# Altium schematic sheet PowerSupply.SchDoc(PowerSupply)
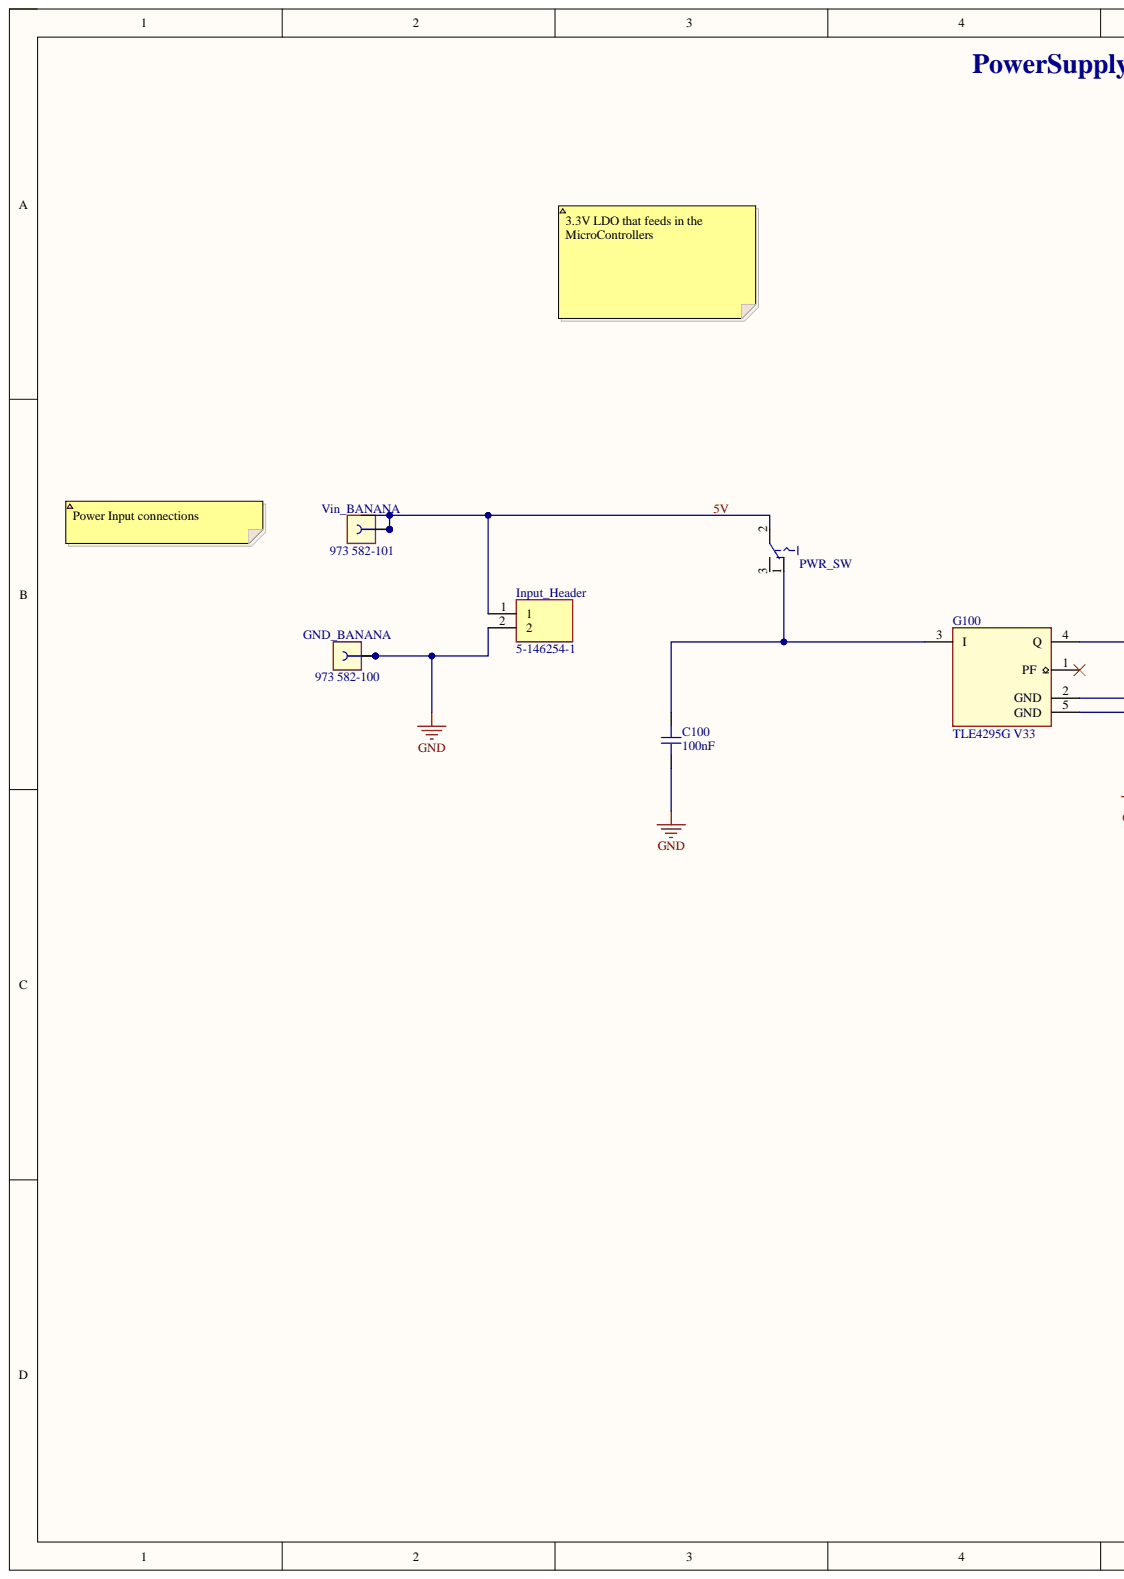
[diagram p0: PowerSupply.SchDoc, left]
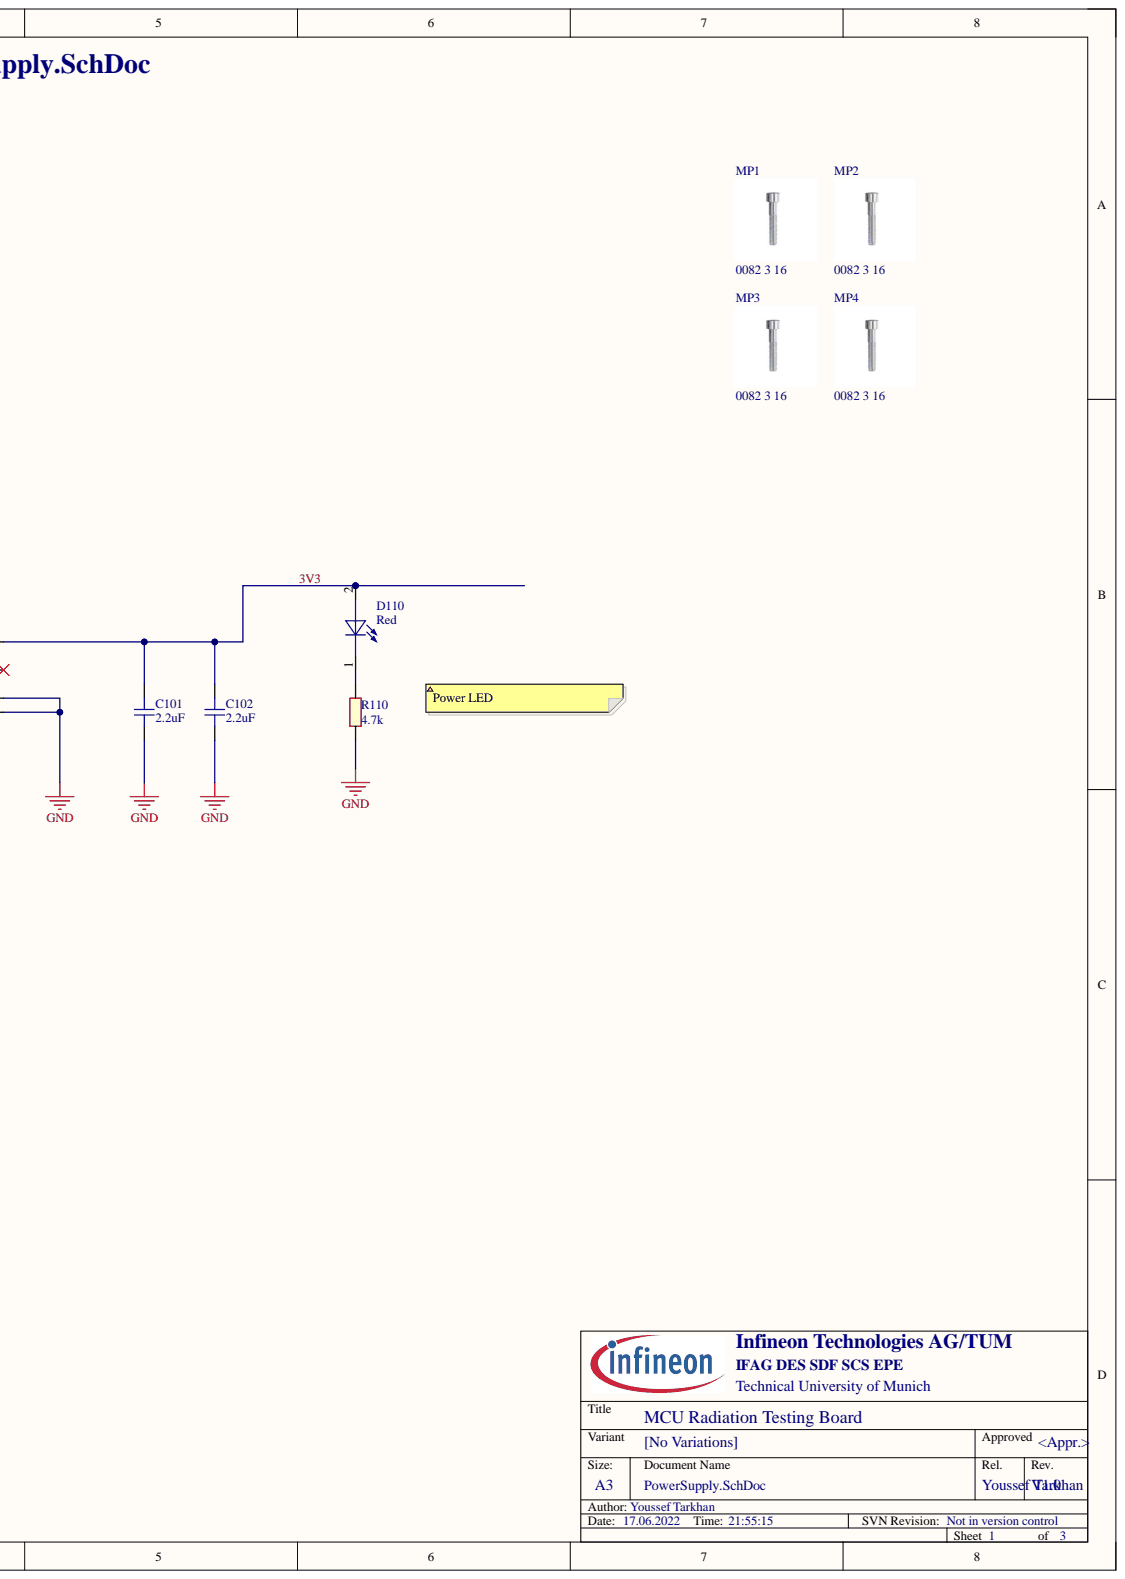
[diagram p1: PowerSupply.SchDoc, right]

## Parts
C100  100nF  [CAPC1608X90N-2]
C101  2.2uF  [CAPC1608X90N-3]
C102  2.2uF  [CAPC1608X90N-3]
D110  Red  [LEDSC160X80X80-2N-1]
G100  TLE4295G V33  [IFX-PG-SCT595-5-2]
GND_BANANA  973 582-100  [CON-THT-973 582- 100]
Input_Header  5-146254-1  [HDRV2W68P254_2X1_213X488X1045P]
MP1  0082 3 16  [SCREW_0082 3 16_NPTH]
MP2  0082 3 16  [SCREW_0082 3 16_NPTH]
MP3  0082 3 16  [SCREW_0082 3 16_NPTH]
MP4  0082 3 16  [SCREW_0082 3 16_NPTH]
PWR_SW  CL-SB-12A-01T  [SW-SMD-CL-SB-12A-01T]  (value not drawn)
R110  4.7k  [RESC1609X55N]
Vin_BANANA  973 582-101  [CON-THT-973 582- 101]

## Nets
GND: C100.1 C101.1 C102.1 G100.2(GND) G100.5(GND) GND_BANANA.1 GND_BANANA.2 Input_Header.2 R110.1
3V3: C101.2 C102.2 D110.2 G100.4(Q)
5V: Input_Header.1 PWR_SW.2 Vin_BANANA.1 Vin_BANANA.2
NetC100_2: C100.2 G100.3(I) PWR_SW.1
NetD110_1: D110.1 R110.2
NetG100_1: G100.1(PF)
NetPWR_SW_3: PWR_SW.3

## Library data of the parts
C100 = 479045: CAP / CERA / 100nF / 50V / 10% / X7R (EIA) / -55°C to 125°C / 0603 / SMD / -; Manufacturer: AVX; Manufacturer Order Number: 06035C104KAT2A; Alternate View: Yes; Automotive Qualified: No; Combined Parameters: TC:X7R (EIA);Tol.:-10%/+10%;Vdc:50V; ComponentStatus: Released; Database Table Name: TPartsAll; PLMStatus: Active; QuickPick: 100nF / 50V / 10% / X7R (EIA) / 0603; Style: Single; Symbol Type: Capacitor; Single; Standard; 2 Pins; Type: Standard; Version Number: V01; Application: Standard; Article Number: 479045; Component Kind: Standard; ComponentLink1Description: Vendor WebSite; ComponentLink1URL: http://www.avx.com; ComponentLink2Description: Datasheet; ComponentLink2URL: http://webnetprod.muc.infineon.com/PCBPortal_Prod/WorkFlows/AltiumComponent/StandardDocumentation?Versionid=479046; Library Name: PCBLibraryData.SVNDbLib; Multiple Parts: No; Package Description: Capacitor,non-polarized,Chip;1.60mm L X 0.81mm W X 0.90mm H; PackageReference: 0603; Part Type: Capacitor; ROHS Compliant: Y; Second Source allowed: Yes; Supplier 1: Farnell; Supplier 2: DigiKey; Supplier 3: Mouser; Supplier Part Number 1: 2280883; Supplier Part Number 2: 478-5052-2-ND; Supplier Part Number 3: 581-06035C104KAT2A; Technology: Ceramic; Temperature max: 125; Temperature min: -55; Temperature Range: Military; Tolerance Neg: 10%; Tolerance Pos: 10%; Value: 100nF; Voltage DC (max): 50V
C101 C102 = 310650: CAP / CERA / 2.2uF / 25V / 10% / X5R (EIA) / -55°C to 85°C / 0603(1608) / SMD / -; Manufacturer: MuRata; Manufacturer Order Number: GRM188R61E225KA12D; Alternate View: Yes; Automotive Qualified: No; Combined Parameters: TC:X5R (EIA);Tol.:-10%/+10%;Vdc:25V; ComponentStatus: Released; Database Table Name: TPartsAll; Legacy DeviceID: CAP01010924; Package Imperial: 0603; Package Metric: 1608; PLMStatus: Active; QuickPick: 2.2uF / 25V / 10% / X5R (EIA) / 0603 (1608M); Style: Single; Symbol Type: Capacitor; Single; Standard; 2 Pins; Type: Standard; Version Number: V01; Article Number: 310650; Component Kind: Standard; ComponentLink1Description: Vendor WebSite; ComponentLink1URL: http://www.murata.com/; ComponentLink2Description: Datasheet; ComponentLink2URL: http://webnetprod.muc.infineon.com/PCBPortal_Prod/WorkFlows/AltiumComponent/StandardDocumentation?Versionid=310651; Legacy PartNumber: CAP01010924; Library Name: PCBLibraryData.SVNDbLib; Multiple Parts: No; Package Description: Capacitor,non-polarized,Chip;1.60mm L X 0.80mm W X 0.90mm H; PackageReference: 0603 (1608M); Part Type: Capacitor; ROHS Compliant: Y; Second Source allowed: Yes; Supplier 1: Farnell; Supplier 2: DigiKey; Supplier 3: Mouser; Supplier Part Number 1: 1845734RL; Supplier Part Number 2: 490-10731-2-ND; Supplier Part Number 3: 81-GRM188R61E225KA2D; Technology: Ceramic; Temperature max: 85; Temperature min: -55; Temperature Range: Military; Tolerance Neg: 10%; Tolerance Pos: 10%; Value: 2.2uF; Voltage DC (max): 25V
D110 = 625459: WL-SMCW SMT Mono-color Chip LED Waterclear, Red,630nm; Manufacturer: Wurth Elektronik; Manufacturer Order Number: 150060RS75000; Automotive Qualified: No; Combined Parameters: Vf:2.4V;If:30mA;Ur:5V;Ir:10uA;Pmax:72mW; ComponentStatus: Released; Database Table Name: TPartsAll; PLMStatus: Active; QuickPick: LED / 1608 (0603); Second Source allowed: Yes; Style: Single; Symbol Type: Standard LED Symbol, 1 Cathode, 2 Anode; Version Number: V01; Voltage DC (max): na; Application: Standard; Article Number: 625459; Component Kind: Standard; ComponentLink1Description: Vendor WebSite; ComponentLink1URL: http://www.we-online.com; ComponentLink2Description: Datasheet; ComponentLink2URL: http://webnetprod.muc.infineon.com/PCBPortal_Prod/WorkFlows/AltiumComponent/StandardDocumentation?Versionid=625460; Library Name: PCBLibraryData.SVNDbLib; Package Description: LED,Side Concave; 2 pin,1.60mm W X 0.80mm L X 0.80mm H; PackageReference: 1608 (0603); Part Type: Diode; ROHS Compliant: Y; Supplier 1: Farnell; Supplier 2: DigiKey; Supplier 3: Mouser; Supplier Part Number 1: 2322071; Supplier Part Number 2: 732-4978-1-ND; Supplier Part Number 3: 710-150060RS75000; Temperature max: 85; Temperature min: -40; Temperature Range: Industrial; Type: LED; Value: Red
G100 = 617559: Manufacturer: Infineon Technologies; Alternate View: No; Automotive Qualified: Yes; Combined Parameters: - - -;Vo:3.17V to 3.43V; ComponentStatus: Released; Database Table Name: TPartsAll; Legacy DeviceID: IFX_TLE4295G V33; PLMStatus: Active; QuickPick: PG-SCT595-5-2; Symbol Type: 5-V Voltage Regulator; Version Number: V01; Voltage DC (max): na; Article Number: 617559; Component Kind: Standard; ComponentLink1Description: Vendor WebSite; ComponentLink1URL: https://www.infineon.com/; ComponentLink2Description: Datasheet; ComponentLink2URL: http://webnetprod.muc.infineon.com/PCBPortal_Prod/WorkFlows/AltiumComponent/StandardDocumentation?Versionid=617560; Library Name: PCBLibraryData.SVNDbLib; Manufacturer Order Number: TLE4295G V33; Multiple Parts: No; Package Description: PG-SCT595-5-2; 5 pin, 1.60 mm L X 2.90 mm W X 1.1 mm H body; PackageReference: PG-SCT595-5-2; Part Type: Power Supply; ROHS Compliant: Y; Second Source allowed: Yes; Supplier 1: Farnell; Supplier 2: DigiKey; Supplier 3: Mouser; Supplier Part Number 1: 2762385; Supplier Part Number 2: 448-TLE4295GV33HTSA1CT-ND; Supplier Part Number 3: 726-TLE4295GV33HTSA1; Temperature max: 150; Temperature min: -40; Temperature Range: Automotive; Value: TLE4295G V33
GND_BANANA = 377401: Banana Socket, black, 17.5mm Pitch; Legacy PartNumber: CON01500045; Manufacturer: Hirschmann Test & Measurement; Manufacturer Component Status: Active; Automotive Qualified: No; Combined Parameters: Board-to-Cable Socket (female);-x-;Mounting:THT;Orient:90° (right angle);Shielded:No; ComponentStatus: Released; Database Table Name: TPartsAll; Legacy DeviceID: HTM_973 582- 100; QuickPick: 90° (right angle) / Board-to-Cable; Second Source allowed: Yes; Symbol Type: Banana Socket 2 Pins; Version Number: V01; Voltage DC (max): na; Article Number: 377401; Component Kind: Standard; ComponentLink1Description: Vendor WebSite; ComponentLink1URL: http://www.sks-kontakt.de/; ComponentLink2Description: Datasheet; ComponentLink2URL: http://webnetprod.muc.infineon.com/PCBPortal_Prod/WorkFlows/AltiumComponent/StandardDocumentation?Versionid=377402; Library Name: PCBLibraryData.SVNDbLib; Manufacturer Order Number: 973 582-100; Package Description: Banana Jack, 2 pins, 17.5mm Pitch, 21mm L X 7.5mm W X 10.5mm H body; Part Type: Connector; PLMStatus: Active; ROHS Compliant: N; Style: Banana; Supplier 1: Farnell; Supplier 2: DigiKey; Supplier 3: Mouser; Supplier Part Number 1: 497344; Supplier Part Number 3: 845-973582100; Temperature max: 85; Temperature min: -25; Temperature Range: Military; Type: Board-to-Cable; Value: 973 582-100
Input_Header = 5-146254-1: Connector; Manufacturer_Name: TE Connectivity; Component Kind: Standard; Datasheet Link: https://www.te.com/commerce/DocumentDelivery/DDEController?Action=srchrtrv&DocNm=146254&DocType=Customer+Drawing&DocLang=English; Height: 10.45mm; Manufacturer_Part_Number: 5-146254-1
MP1-MP4 = 455343: Cheese Head Screw With Hexagon Socket, DIN 912 (ISO 4762), M3, 16mm length; Legacy PartNumber: MEC10000167; Manufacturer: Wurth Elektronik; Alternate View: No; Combined Parameters: Steel Hexagonal 19x5.68 Screw; ComponentStatus: Released; Database Table Name: TPartsAll; Legacy DeviceID: MEC_0082 3 16; PLMStatus: Active; Second Source allowed: Yes; Style: Hexagonal; Symbol Type: Cheese-Head Screw, M2.5X5-DIN 912; Version Number: V01; Voltage DC (max): na; Article Number: 455343; Automotive Qualified: No; Component Kind: Standard; ComponentLink1Description: Vendor WebSite; ComponentLink1URL: http://www.we-online.com; ComponentLink2Description: Datasheet; ComponentLink2URL: http://webnetprod.muc.infineon.com/PCBPortal_Prod/WorkFlows/AltiumComponent/StandardDocumentation?Versionid=455344; Library Name: PCBLibraryData.SVNDbLib; Manufacturer Order Number: 0082 3 16; Multiple Parts: No; Package Description: M3 Cheese Head Screw X 5.68mm Head; PackageReference: THT; Part Type: Mechanical; QuickPick: Screw; ROHS Compliant: N; Supplier 1: Farnell; Supplier 2: DigiKey; Supplier 3: Mouser; Temperature max: 0; Temperature min: 0; Temperature Range: Military; Type: Screw; Value: 0082 3 16
PWR_SW = 650099: Slid Switch, 12V, 200mA; Manufacturer: NIDEC COPAL ELECTRONICS, Inc.; Automotive Qualified: No; ComponentStatus: Released; Database Table Name: TPartsAll; PLMStatus: Active; Second Source allowed: Yes; Symbol Type: Switch, 2 Change Over 1 3, 3 Pins; Version Number: V00; Voltage DC (max): na; Application: Power; Article Number: 650099; Component Kind: Standard; ComponentLink1Description: Vendor WebSite; ComponentLink1URL: www.copal-electronics.com/; ComponentLink2Description: Datasheet; ComponentLink2URL: http://webnetprod.muc.infineon.com/PCBPortal_Prod/WorkFlows/AltiumComponent/StandardDocumentation?Versionid=650100; Library Name: PCBLibraryData.SVNDbLib; Manufacturer Order Number: CL-SB-12A-01T; Package Description: Switch, SMD, 3 Pins, 3.50 mm W X 8.50 mm L X 6.10 mm H body; Part Type: Electro Mechanic; ROHS Compliant: Y; Supplier 1: Farnell; Supplier 2: DigiKey; Supplier 3: Mouser; Supplier Part Number 1: 2854744; Supplier Part Number 2: 563-1314-1-ND; Supplier Part Number 3: 229-CL-SB-12A-01T; Temperature max: 85; Temperature min: -40; Temperature Range: Industrial; Value: CL-SB-12A-01T
R110 = 699381: RES / STD / 4.7k / 100mW / 1% / 100ppm/K / -55°C to 155°C / 1.60mm L X 0.85mm W X 0.55mm H / - / -; Manufacturer: Panasonic; Manufacturer Order Number: ERJ3EKF4701V; Multiple Parts: No; Alternate View: Yes; Automotive Qualified: AEC Q200; Combined Parameters: TCR:100ppm/K;Tol.:-1%/+1%;Vdc:-;Idc:-;P:100mW; ComponentStatus: Released; Database Table Name: TPartsAll; PLMStatus: Active; QuickPick: 4.7k / 1% / 0.1 / ERJ3EKF4701V; Style: Single; Symbol Type: Resistor; Single; Standard; 2 Pins; Version Number: V00; Application: Standard; Article Number: 699381; Component Kind: Standard; ComponentLink1Description: Vendor WebSite; ComponentLink1URL: http://panasonic.net/sanyo/index.html; ComponentLink2Description: Datasheet; ComponentLink2URL: http://webnetprod.muc.infineon.com/PCBPortal_Prod/WorkFlows/AltiumComponent/StandardDocumentation?Versionid=699382; Library Name: PCBLibraryData.SVNDbLib; Package Description: Resistor,Chip;1.60mm L X 0.85mm W X 0.55mm H; PackageReference: ERJ3EKF4701V; Part Type: Resistor; ROHS Compliant: Y; Second Source allowed: Yes; Supplier 1: Farnell; Supplier 2: DigiKey; Supplier 3: Mouser; Supplier Part Number 1: 2303181; Supplier Part Number 2: P4.70KHCT-ND; Supplier Part Number 3: 667-ERJ-3EKF4701V; Technology: Thick Film; Temperature max: 155; Temperature min: -55; Temperature Range: Military; Tolerance Neg: 1%; Tolerance Pos: 1%; Type: Standard; Value: 4.7k
Vin_BANANA = 377341: Banana Socket, Red, 17.5mm Pitch; Legacy PartNumber: CON01500041; Manufacturer: Hirschmann Test & Measurement; Manufacturer Component Status: Active; Combined Parameters: Board-to-Cable Socket (female);2x1;Mounting:THT;Orient:90° (right angle);Shielded:No; ComponentStatus: Released; Database Table Name: TPartsAll; Legacy DeviceID: HTM_973 582- 101; QuickPick: 90° (right angle) / Board-to-Cable; Second Source allowed: Yes; Symbol Type: Banana Socket 2 Pins; Version Number: V01; Voltage DC (max): na; Article Number: 377341; Component Kind: Standard; ComponentLink1Description: Vendor WebSite; ComponentLink1URL: http://www.sks-kontakt.de/; ComponentLink2Description: Datasheet; ComponentLink2URL: http://webnetprod.muc.infineon.com/PCBPortal_Prod/WorkFlows/AltiumComponent/StandardDocumentation?Versionid=377342; Library Name: PCBLibraryData.SVNDbLib; Manufacturer Order Number: 973 582-101; Package Description: Banana Jack, 2 pins, 17.5mm Pitch, 21mm L X 7.5mm W X 10.5mm H body; Part Type: Connector; PLMStatus: Active; ROHS Compliant: N; Style: Banana; Supplier 1: Farnell; Supplier 2: DigiKey; Supplier 3: Mouser; Supplier Part Number 1: 497332; Supplier Part Number 3: 845-973582101; Temperature max: 85; Temperature min: -25; Temperature Range: Military; Type: Board-to-Cable; Value: 973 582-101
